# Altium schematic sheet belt_motor_control.SchDoc(belt_motor_control)
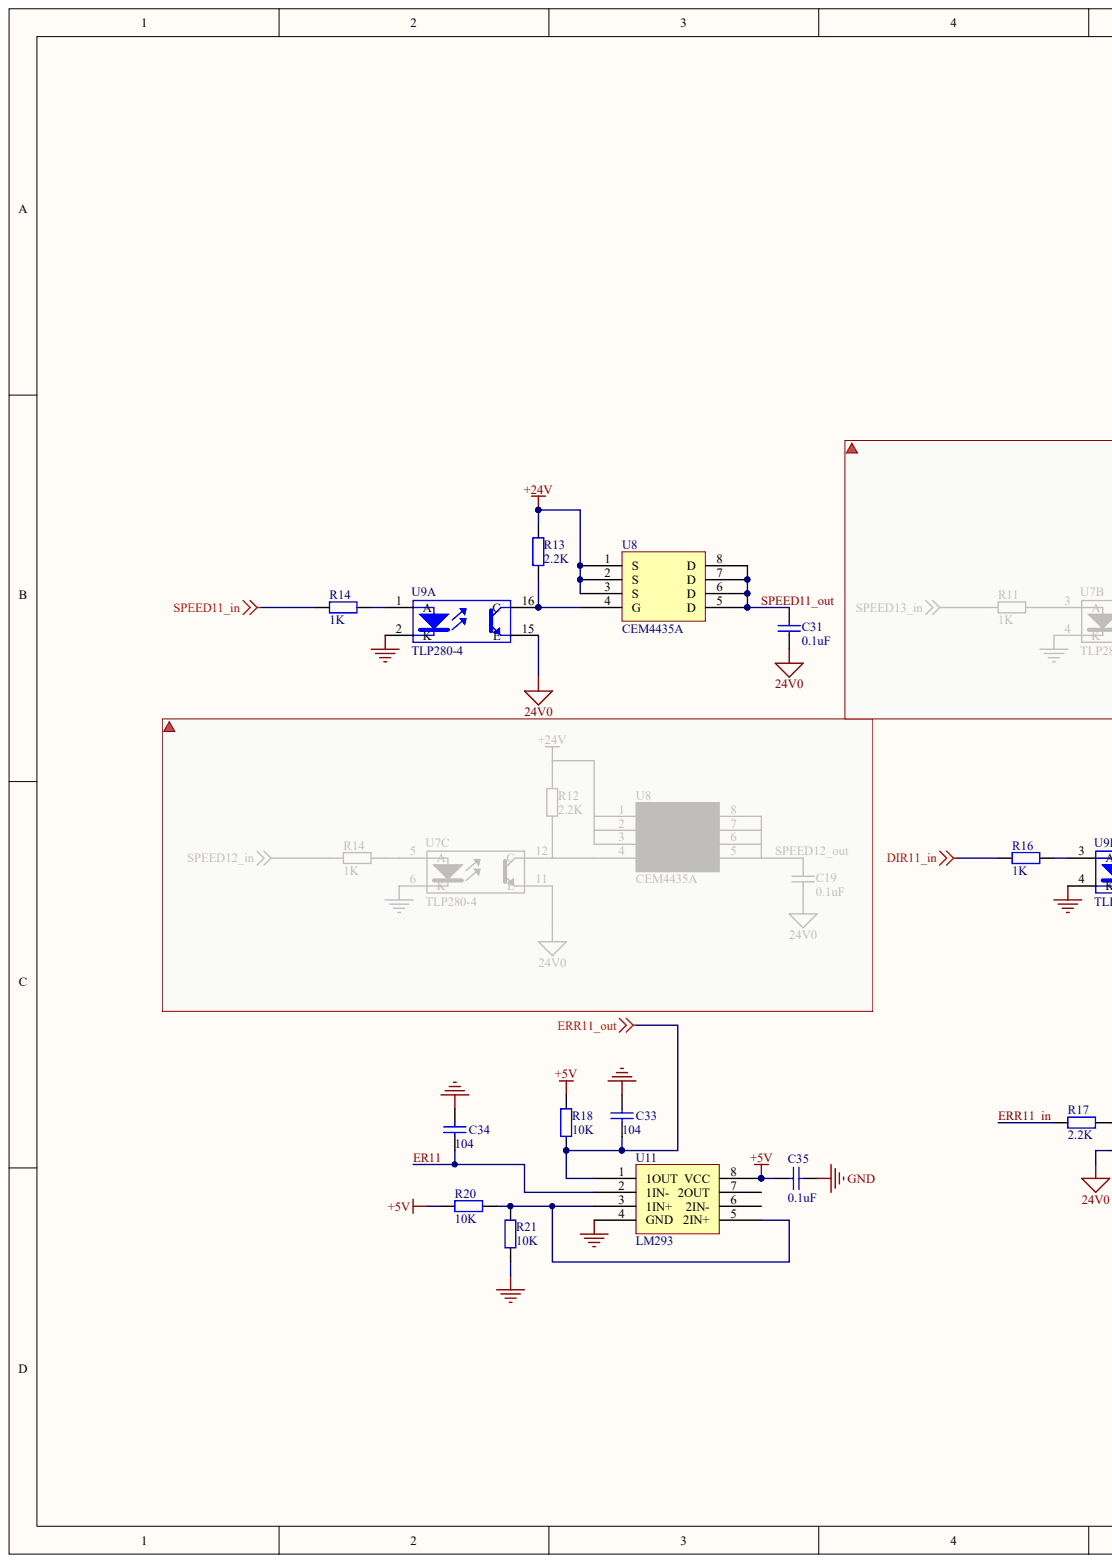
[diagram p0: belt_motor_control.SchDoc, left]
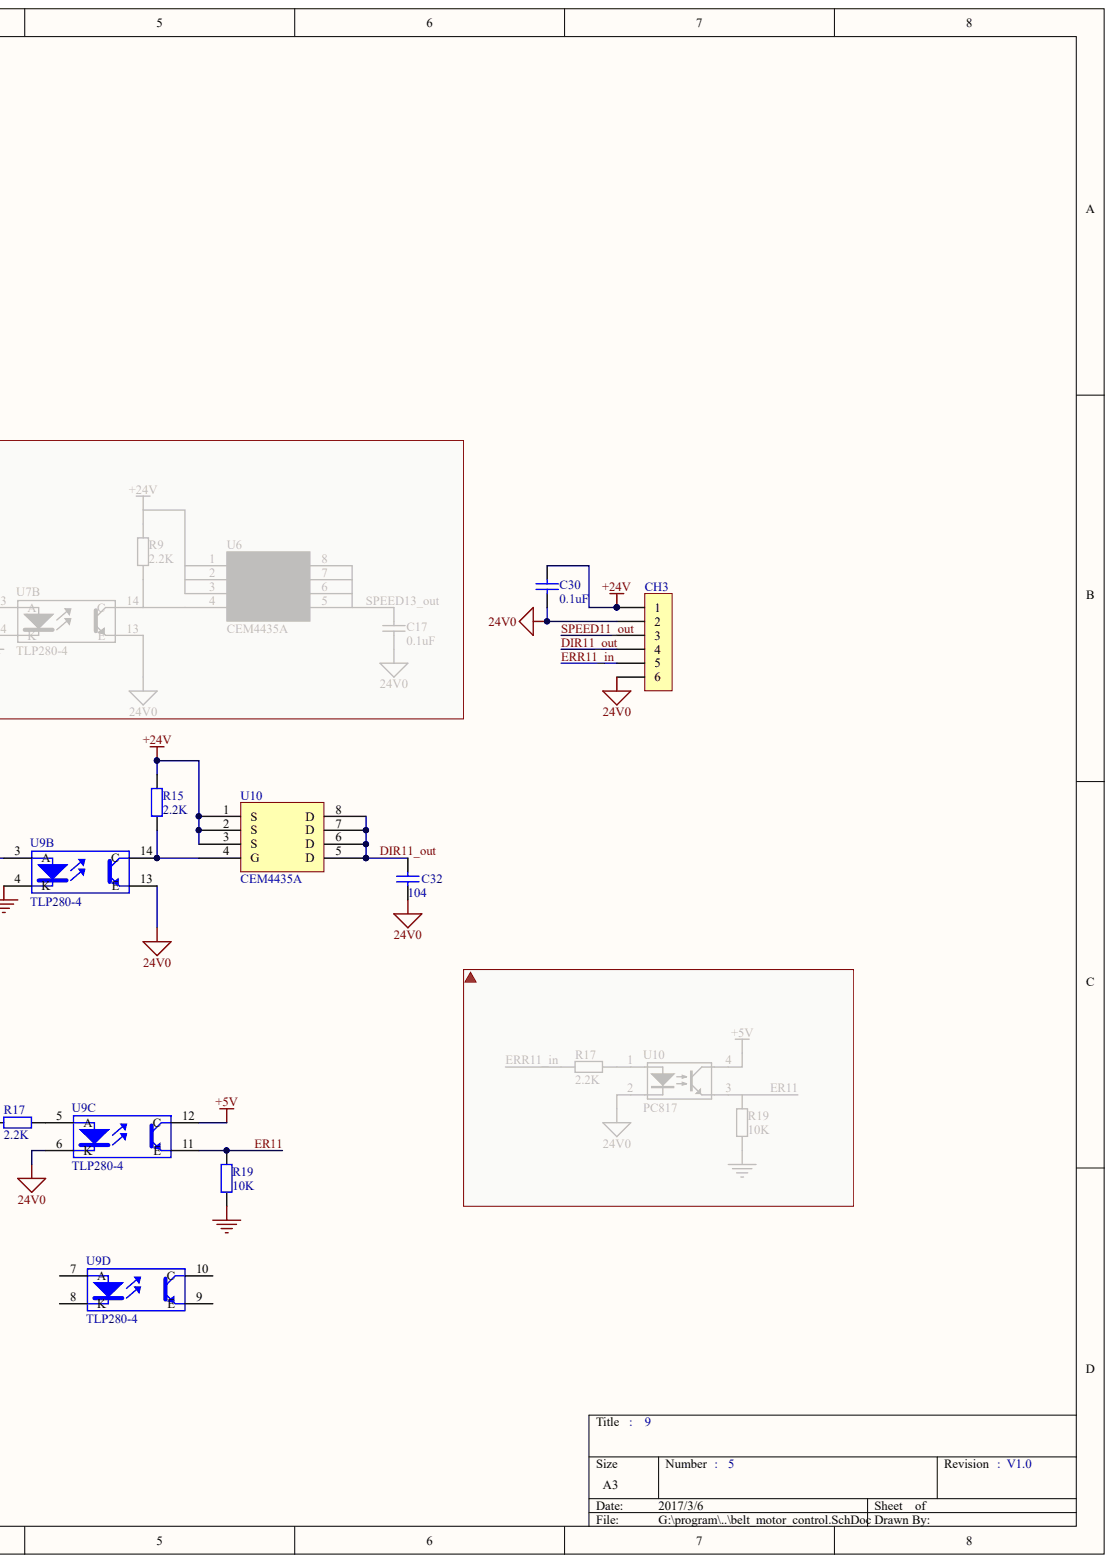
[diagram p1: belt_motor_control.SchDoc, right]

## Parts
C30  value 0.1uF  (hidden comment: Cap)
C31  value 0.1uF  (hidden comment: Cap)
C32  value 104  (hidden comment: Cap)
C33  value 104  (hidden comment: Cap)
C34  value 104  (hidden comment: Cap)
C35  value 0.1uF  (hidden comment: Cap)
CH3  HEADER1X6  (value not drawn)
R13  value 2.2K  (hidden comment: Res2)
R14  value 1K  (hidden comment: Res2)
R15  value 2.2K  (hidden comment: Res2)
R16  value 1K  (hidden comment: Res2)
R17  value 2.2K  (hidden comment: Res2)
R18  value 10K  (hidden comment: Res2)
R19  value 10K  (hidden comment: Res2)
R20  value 10K  (hidden comment: Res2)
R21  value 10K  (hidden comment: Res2)
U8  CEM4435A
U9  TLP280-4  (parts A,B,C,D)
U10  CEM4435A
U11  LM293

## Nets
24V0: C30.2 C31.2 C32.1 CH3.2 CH3.6 U9.6(K) U9.13(E) U9.15(E)
+5V: C35.2 R18.1 R20.1 U9.12(C) U11.8(VCC)
+24V: C30.1 CH3.1 R13.2 R15.1 U8.1(S) U8.2(S) U8.3(S) U10.1(S) U10.2(S) U10.3(S)
DIR11_IN: R16.1
ERR11_OUT: C33.1 R18.2 U11.1(1OUT)
GND: C33.2 C34.2 C35.1 R19.1 R21.2 U9.2(K) U9.4(K) U11.4(GND)
SPEED11_IN: R14.1
DIR11_OUT: C32.2 CH3.4 U10.5(D) U10.6(D) U10.7(D) U10.8(D)
ER11: C34.1 R19.2 U9.11(E) U11.2(1IN-)
ERR11_IN: CH3.5 R17.1
SPEED11_OUT: C31.1 CH3.3 U8.5(D) U8.6(D) U8.7(D) U8.8(D)
NetR13_1: R13.1 U8.4(G) U9.16(C)
NetR14_2: R14.2 U9.1(A)
NetR15_2: R15.2 U9.14(C) U10.4(G)
NetR16_2: R16.2 U9.3(A)
NetR17_2: R17.2 U9.5(A)
NetR20_2: R20.2 R21.1 U11.3(1IN+) U11.5(2IN+)

Not connected: U9 7(A) 8(K) 9(E) 10(C); U11 6(2IN-) 7(2OUT)

## Library data of the parts
C30-C35 CH3 R13-R21 U8-U11 = (no symbol name)
  C30-C35: Published: 8-Jun-2000; LatestRevisionDate: 17-Jul-2002; LatestRevisionNote: Re-released for DXP Platform.; PackageReference: RAD-0.3
  R13-R21: Published: 8-Jun-2000; LatestRevisionDate: 17-Jul-2002; LatestRevisionNote: Re-released for DXP Platform.; PackageReference: AXIAL-0.4
  C30-C35 R13-R21: Publisher: Altium Limited
